# Altium schematic sheet Otto Rev E1.SchDoc
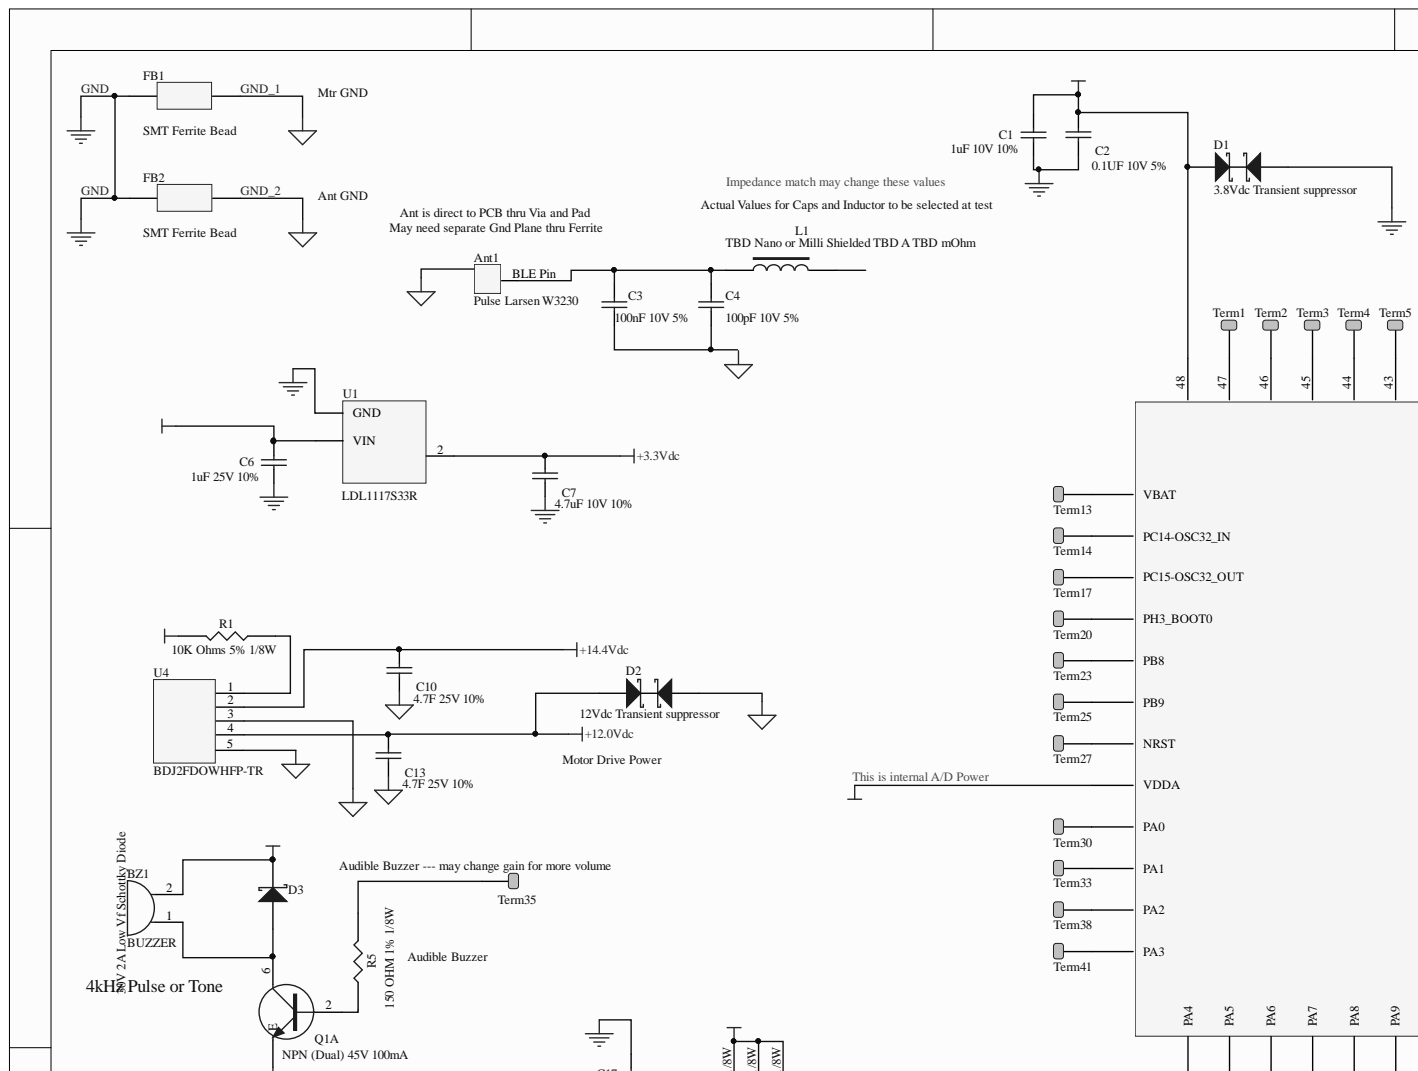
[diagram p0: Otto Rev E1.SchDoc, top left]
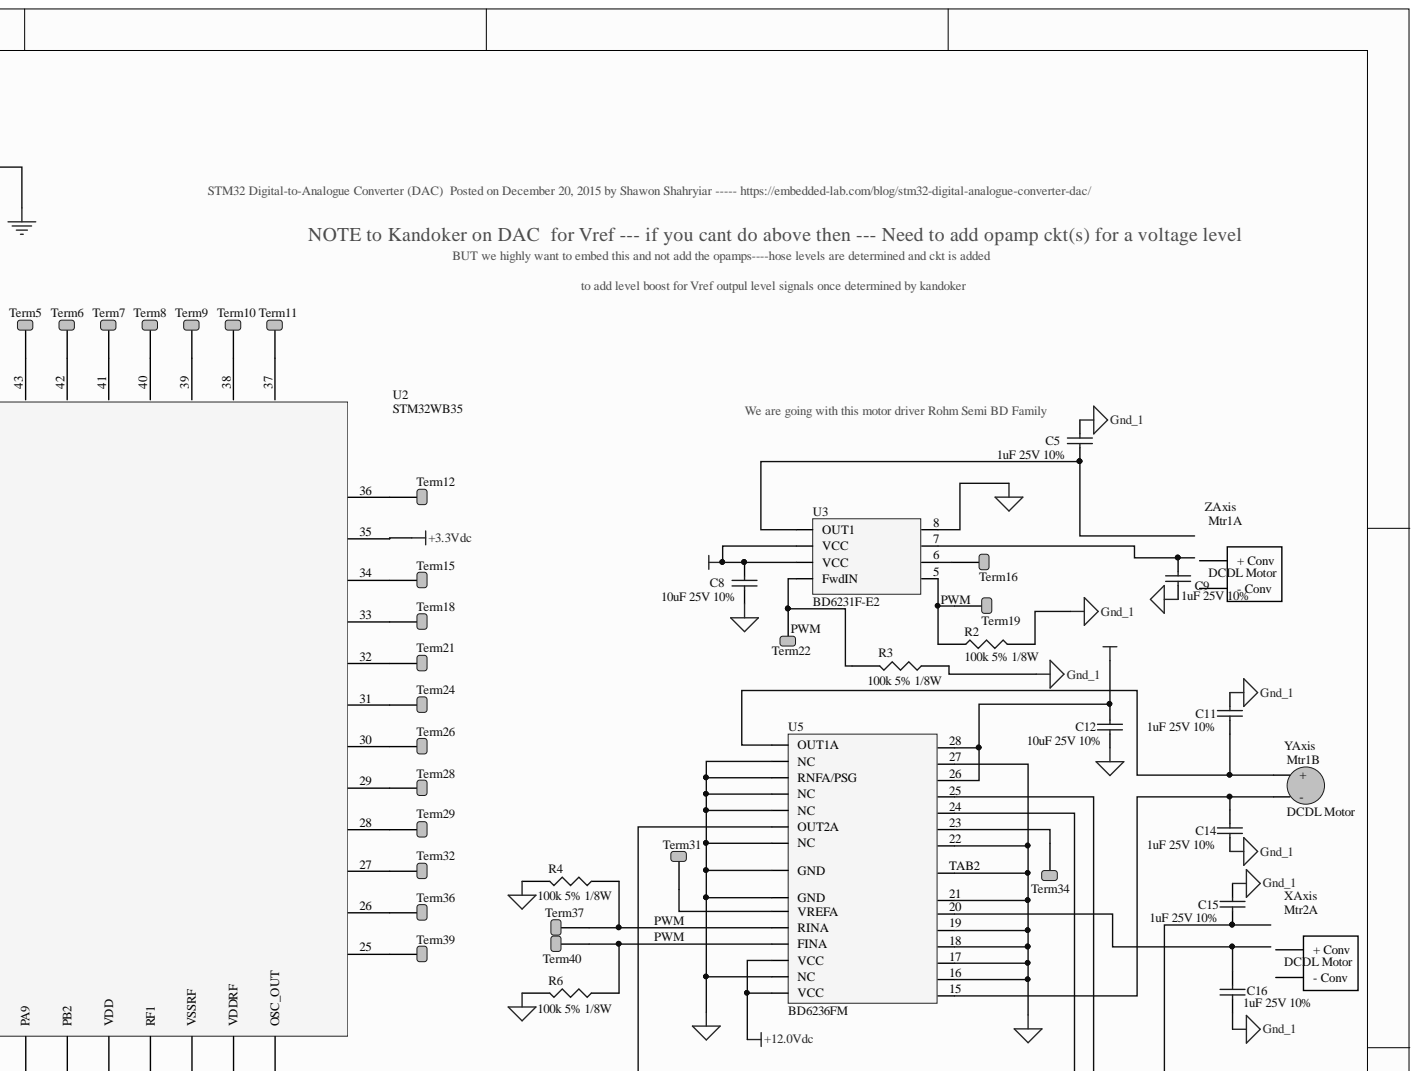
[diagram p1: Otto Rev E1.SchDoc, top right]
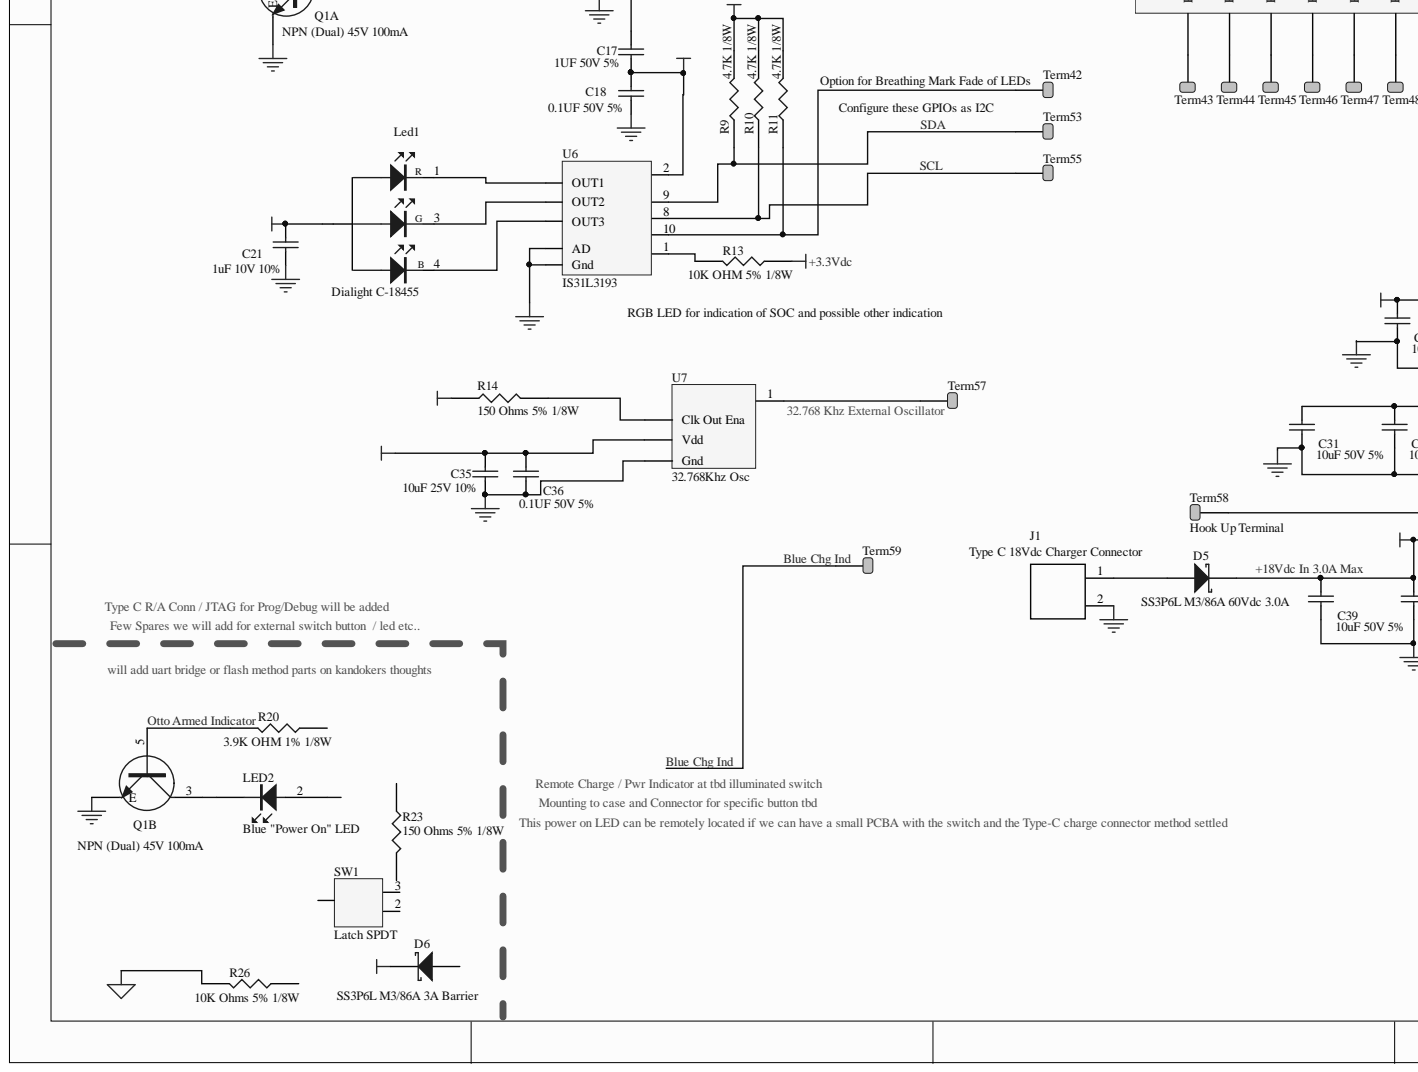
[diagram p2: Otto Rev E1.SchDoc, bottom left]
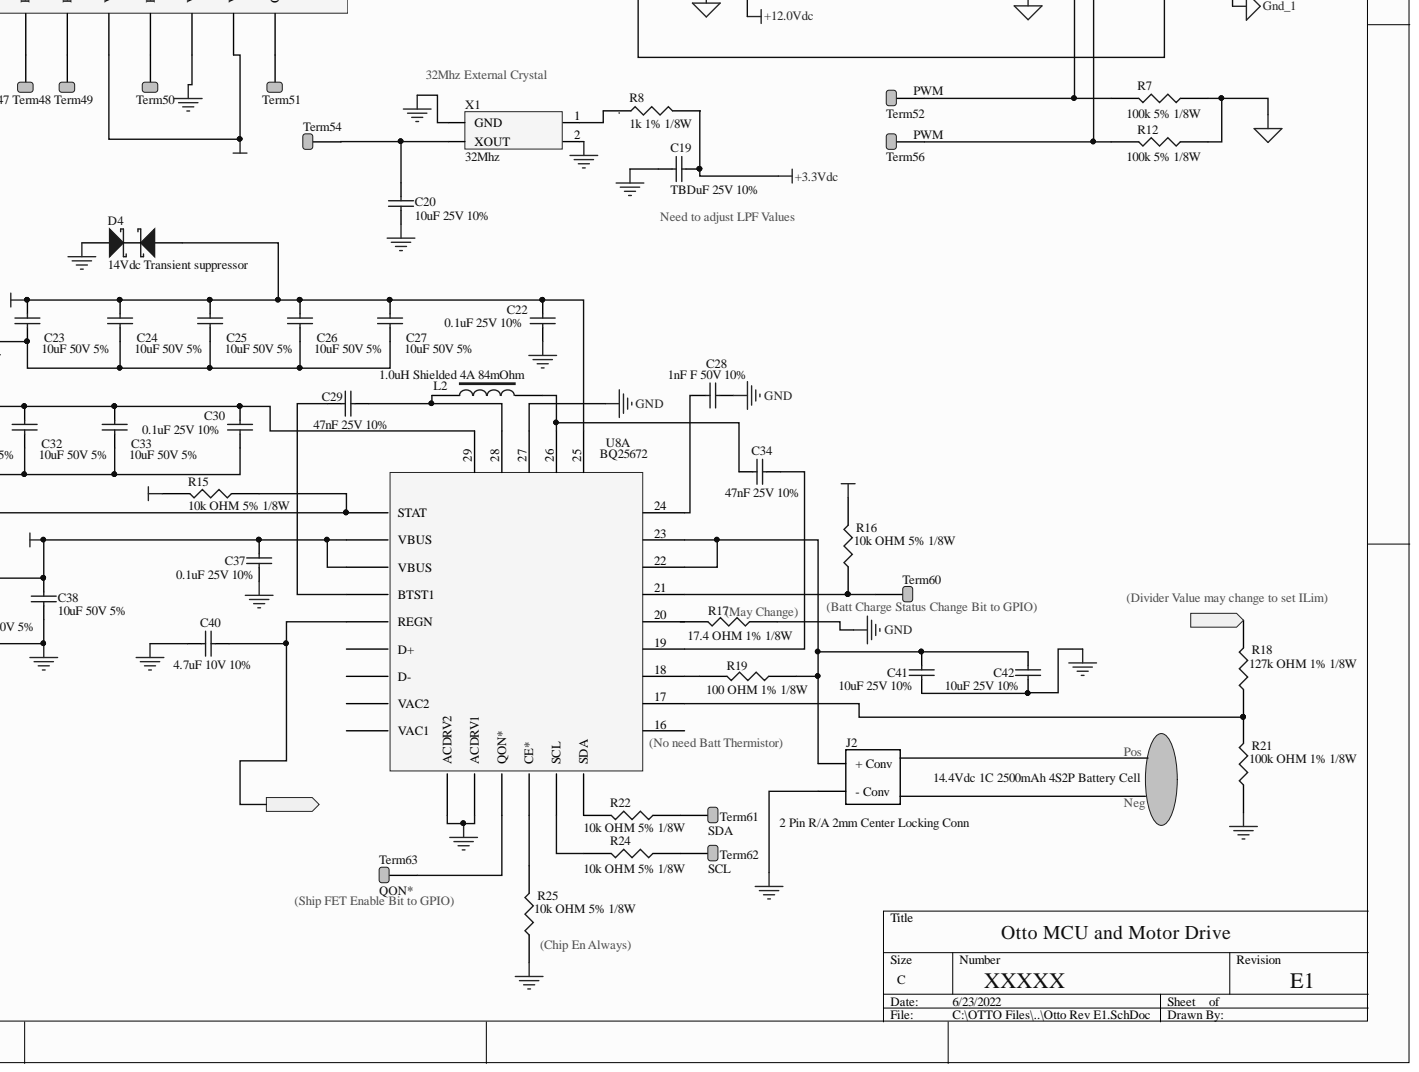
[diagram p3: Otto Rev E1.SchDoc, bottom right]

## Parts
Ant1  Pulse Larsen W3230
BZ1  BUZZER  [BUZZER-smd]
C1  1uF 10V 10%  [0805]
C2  0.1UF 10V 5%  [0603]
C3  100nF 10V 5%  [0805]
C4  100pF 10V 5%  [0603]
C5  1uF 25V 10%  [0805]
C6  1uF 25V 10%  [0805]
C7  4.7uF 10V 10%  [0603]
C8  10uF 25V 10%  [0805]
C9  1uF 25V 10%  [0805]
C10  4.7F 25V 10%  [0603]
C11  1uF 25V 10%  [0805]
C12  10uF 25V 10%  [0805]
C13  4.7F 25V 10%  [0603]
C14  1uF 25V 10%  [0805]
C15  1uF 25V 10%  [0805]
C16  1uF 25V 10%  [0805]
C17  1UF 50V 5%  [0603]
C18  0.1UF 50V 5%  [0603]
C19  TBDuF 25V 10%  [0805]
C20  10uF 25V 10%  [0805]
C21  1uF 10V 10%  [0603]
C22  0.1uF 25V 10%  [0805]
C23  10uF 50V 5%  [0603]
C24  10uF 50V 5%  [0603]
C25  10uF 50V 5%  [0603]
C26  10uF 50V 5%  [0603]
C27  10uF 50V 5%  [0603]
C28  1nF F 50V 10%  [0805]
C29  47nF 25V 10%  [0805]
C30  0.1uF 25V 10%  [0805]
C31  10uF 50V 5%  [0603]
C32  10uF 50V 5%  [0603]
C33  10uF 50V 5%  [0603]
C34  47nF 25V 10%  [0805]
C35  10uF 25V 10%  [0805]
C36  0.1UF 50V 5%  [0603]
C37  0.1uF 25V 10%  [0805]
C38  10uF 50V 5%  [0603]
C39  10uF 50V 5%  [0603]
C40  4.7uF 10V 10%  [0805]
C41  10uF 25V 10%  [0805]
C42  10uF 25V 10%  [0805]
D1  3.8Vdc Transient suppressor  [SOD 123]
D2  12Vdc Transient suppressor  [SOD 123]
D3  30V 2A Low Vf Schottky Diode  [SOD 123]
D4  14Vdc Transient suppressor  [SOD 123]
D5  SS3P6L M3/86A 60Vdc 3.0A  [Vishay Diode]
D6  SS3P6L M3/86A 3A Barrier  [Vishay Diode]
FB1  Text Field1: SMT Ferrite Bead  [0805]  (hidden comment: Max DCR 0.05ohm  3A)
FB2  Text Field1: SMT Ferrite Bead  [0805]  (hidden comment: Max DCR 0.05ohm  3A)
J1  Type C 18Vdc Charger Connector  [GTK 2 pin Batt  RA]
J2  2 Pin R/A 2mm Center Locking Conn  [GTK 2 pin Batt  RA]
L1  TBD Nano or Milli Shielded TBD A TBD mOhm  [4x4 INDUCTOR]
L2  1.0uH Shielded 4A 84mOhm  [4x4 INDUCTOR]
Led1  Dialight C-18455
LED2  Blue "Power On" LED  [LED]
Mtr1  DCDL Motor  [GTK 2 pin Batt  RA]  (parts A,B)
Mtr2  DCDL Motor  [GTK 2 pin Batt  RA]  (part A)
Q1  NPN (Dual) 45V 100mA  [SOT-363]  (parts A,B)
R1  10K Ohms 5% 1/8W  [0805]
R2  100k 5% 1/8W  [0805]
R3  100k 5% 1/8W  [0805]
R4  100k 5% 1/8W  [0805]
R5  150 OHM 1% 1/8W  [0805]
R6  100k 5% 1/8W  [0805]
R7  100k 5% 1/8W  [0805]
R8  1k 1% 1/8W  [0805]
R9  4.7K 1/8W  [0805]
R10  4.7K 1/8W  [0805]
R11  4.7K 1/8W  [0805]
R12  100k 5% 1/8W  [0805]
R13  10K OHM 5% 1/8W  [0805]
R14  150 Ohms 5% 1/8W  [0805]
R15  10k OHM 5% 1/8W  [0805]
R16  10k OHM 5% 1/8W  [0805]
R17  17.4 OHM 1% 1/8W  [0805]
R18  127k OHM 1% 1/8W  [0805]
R19  100 OHM 1% 1/8W  [0805]
R20  3.9K OHM 1% 1/8W  [0805]
R21  100k OHM 1% 1/8W  [0805]
R22  10k OHM 5% 1/8W  [0805]
R23  150 Ohms 5% 1/8W  [0805]
R24  10k OHM 5% 1/8W  [0805]
R25  10k OHM 5% 1/8W  [0805]
R26  10K Ohms 5% 1/8W  [0805]
SW1  Latch SPDT
Term1  Hook Up Terminal  [Vishay Diode]
Term2  Hook Up Terminal  [Vishay Diode]
Term3  Hook Up Terminal  [Vishay Diode]
Term4  Hook Up Terminal  [Vishay Diode]
Term5  Hook Up Terminal  [Vishay Diode]
Term6  Hook Up Terminal  [Vishay Diode]
Term7  Hook Up Terminal  [Vishay Diode]
Term8  Hook Up Terminal  [Vishay Diode]
Term9  Hook Up Terminal  [Vishay Diode]
Term10  Hook Up Terminal  [Vishay Diode]
Term11  Hook Up Terminal  [Vishay Diode]
Term12  Hook Up Terminal  [Vishay Diode]
Term13  Hook Up Terminal  [Vishay Diode]
Term14  Hook Up Terminal  [Vishay Diode]
Term15  Hook Up Terminal  [Vishay Diode]
Term16  Hook Up Terminal  [Vishay Diode]
Term17  Hook Up Terminal  [Vishay Diode]
Term18  Hook Up Terminal  [Vishay Diode]
Term19  Hook Up Terminal  [Vishay Diode]
Term20  Hook Up Terminal  [Vishay Diode]
Term21  Hook Up Terminal  [Vishay Diode]
Term22  Hook Up Terminal  [Vishay Diode]
Term23  Hook Up Terminal  [Vishay Diode]
Term24  Hook Up Terminal  [Vishay Diode]
Term25  Hook Up Terminal  [Vishay Diode]
Term26  Hook Up Terminal  [Vishay Diode]
Term27  Hook Up Terminal  [Vishay Diode]
Term28  Hook Up Terminal  [Vishay Diode]
Term29  Hook Up Terminal  [Vishay Diode]
Term30  Hook Up Terminal  [Vishay Diode]
Term31  Hook Up Terminal  [Vishay Diode]
Term32  Hook Up Terminal  [Vishay Diode]
Term33  Hook Up Terminal  [Vishay Diode]
Term34  Hook Up Terminal  [Vishay Diode]
Term35  Hook Up Terminal  [Vishay Diode]
Term36  Hook Up Terminal  [Vishay Diode]
Term37  Hook Up Terminal  [Vishay Diode]
Term38  Hook Up Terminal  [Vishay Diode]
Term39  Hook Up Terminal  [Vishay Diode]
Term40  Hook Up Terminal  [Vishay Diode]
Term41  Hook Up Terminal  [Vishay Diode]
Term42  Hook Up Terminal  [Vishay Diode]
Term43  Hook Up Terminal  [Vishay Diode]
Term44  Hook Up Terminal  [Vishay Diode]
Term45  Hook Up Terminal  [Vishay Diode]
Term46  Hook Up Terminal  [Vishay Diode]
Term47  Hook Up Terminal  [Vishay Diode]
Term48  Hook Up Terminal  [Vishay Diode]
Term49  Hook Up Terminal  [Vishay Diode]
Term50  Hook Up Terminal  [Vishay Diode]
Term51  Hook Up Terminal  [Vishay Diode]
Term52  Hook Up Terminal  [Vishay Diode]
Term53  Hook Up Terminal  [Vishay Diode]
Term54  Hook Up Terminal  [Vishay Diode]
Term55  Hook Up Terminal  [Vishay Diode]
Term56  Hook Up Terminal  [Vishay Diode]
Term57  Hook Up Terminal  [Vishay Diode]
Term58  Hook Up Terminal  [Vishay Diode]
Term59  Hook Up Terminal  [Vishay Diode]
Term60  Hook Up Terminal  [Vishay Diode]
Term61  SDA  [Vishay Diode]
Term62  SCL  [Vishay Diode]
Term63  QON*  [Vishay Diode]
U1  LDL1117S33R  [CONN-5]
U2  STM32WB35  [32 Pin]
U3  BD6231F-E2
U4  BDJ2FDOWHFP-TR  [CONN-4]
U5  BD6236FM
U6  IS31L3193
U7  32.768Khz Osc  [CONN-4]
U8  BQ25672  [SOP-8]  (part A)
X1  32Mhz

## Ports
REGN

## Nets
+3.3Vdc: BZ1.2 C1.1 C2.1 C7.1 C17.1 C18.1 C19.2 C21.1 C35.1 C36.1 D1.1 D3.1 Led1.2 R8.1 R9.2 R10.2 R11.2 R13.2 R14.1 R15.2 R16.2 U1.2 U2.8(VDDA) U2.20(VDD) U2.23(VDDRF) U2.35 U2.48 U6.2 U7.4(Vdd)
+12.0Vdc: C8.1 C12.1 C13.1 D2.1 U3.2(VCC) U3.3(VCC) U4.4 U5.12(VCC) U5.14(VCC) U5.26 U5.28
+14.4Vdc: C10.1 C22.1 C23.1 C24.1 C25.1 C26.1 C27.1 D4.2 U4.2 U8.25
+18Vdc: C6.1 C37.1 C38.2 C39.1 D5.1 R1.2 U1.3 U8.2(VBUS) U8.3(VBUS)
VCC: D6.1
Blue Chg Ind: Term59.1
GND: C1.2 C2.2 C6.2 C7.2 C17.2 C18.2 C19.1 C20.2 C21.2 C22.2 C23.2 C24.2 C25.2 C26.2 C27.2 C28.2 C30.2 C31.2 C32.2 C33.2 C37.2 C38.1 C39.2 C40.1 C41.2 C42.2 D1.2 D4.1 FB1.1 FB2.1 J1.2 J2.2 Q1.1(E) Q1.4(E) R17.2 R21.1 R25.1 U1.1 U2.22(VSSRF) U6.6(Gnd) U6.7(AD) U8.10(ACDRV2) U8.11(ACDRV1) U8.27 X1.2 X1.4(GND)
GND_1: C5.1 C8.2 C9.1 C10.2 C11.1 C12.2 C13.2 C14.2 C15.1 C16.1 C35.2 C36.2 D2.2 FB1.2 R2.2 R3.1 R4.2 R6.2 R7.1 R12.1 R26.2 U3.8 U4.3 U4.5 U5.2(NC) U5.3(RNFA/PSG) U5.4(NC) U5.5(NC) U5.7(NC) U5.8(GND) U5.13(NC) U5.16 U5.17 U5.18 U5.19 U5.21 U5.22 U5.27 U5.TAB1(GND) U5.TAB2 U7.2(Gnd)
GND_2: FB2.2
Otto Armed Indicator: Q1.5 R20.1
REGN: C40.2 R18.2 U8.5(REGN) ; port REGN
SCL: R10.1 Term55.1 U6.8
SDA: R9.1 Term53.1 U6.9
NetAnt1_BLE Pin: Ant1.BLE Pin C3.1 C4.1 L1.1
NetBZ1_1: BZ1.1 D3.2 Q1.6
NetC3_2: C3.2 C4.2
NetC5_2: C5.2 U3.1(OUT1)
NetC9_2: C9.2 U3.7
NetC11_2: C11.2 Mtr1.1 U5.1(OUT1A)
NetC14_1: C14.1 Mtr1.2 U5.15
NetC15_2: C15.2 U5.6(OUT2A)
NetC16_2: C16.2 U5.20
NetC20_1: C20.1 Term54.1 X1.3(XOUT)
NetC28_1: C28.1 U8.24
NetC29_1: C29.1 U8.4(BTST1)
NetC29_2: C29.2 L2.1 U8.28
NetC30_1: C30.1 C31.1 C32.1 C33.1 U8.29
NetC34_1: C34.1 L2.2 U8.26
NetC34_2: C34.2 U8.19
NetC41_1: C41.1 C42.1 J2.1 R19.2 U8.22 U8.23
NetD5_2: D5.2 J1.1
NetLed1_1: Led1.1 U6.3(OUT1)
NetLed1_3: Led1.3 U6.4(OUT2)
NetLed1_4: Led1.4 U6.5(OUT3)
NetLED2_1: LED2.1 Q1.3
NetQ1_2: Q1.2 R5.1
NetR1_1: R1.1 U4.1
NetR2_1: R2.1 Term19.1 U3.5
NetR3_2: R3.2 Term22.1 U3.4(FwdIN)
NetR4_1: R4.1 Term37.1 U5.10(RINA)
NetR5_2: R5.2 Term35.1
NetR6_1: R6.1 Term40.1 U5.11(FINA)
NetR7_2: R7.2 Term52.1 U5.24
NetR8_2: R8.2 X1.1
NetR11_1: R11.1 Term42.1 U6.10
NetR12_2: R12.2 Term56.1 U5.25
NetR13_1: R13.1 U6.1
NetR14_2: R14.2 U7.3(Clk_Out_Ena)
NetR15_1: R15.1 Term58.1 U8.1(STAT)
NetR16_1: R16.1 Term60.1 U8.21
NetR18_1: R18.1 R21.2 U8.17
NetR19_1: R19.1 U8.18
NetR22_1: R22.1 Term61.1
NetR22_2: R22.2 U8.15(SDA)
NetR24_1: R24.1 Term62.1
NetR24_2: R24.2 U8.14(SCL)
NetR25_2: R25.2 U8.13(CE*)
NetTerm1_1: Term1.1 U2.47
NetTerm2_1: Term2.1 U2.46
NetTerm3_1: Term3.1 U2.45
NetTerm4_1: Term4.1 U2.44
NetTerm5_1: Term5.1 U2.43
NetTerm6_1: Term6.1 U2.42
NetTerm7_1: Term7.1 U2.41
NetTerm8_1: Term8.1 U2.40
NetTerm9_1: Term9.1 U2.39
NetTerm10_1: Term10.1 U2.38
NetTerm11_1: Term11.1 U2.37
NetTerm12_1: Term12.1 U2.36
NetTerm13_1: Term13.1 U2.1(VBAT)
NetTerm14_1: Term14.1 U2.2(PC14-OSC32_IN)
NetTerm15_1: Term15.1 U2.34
NetTerm16_1: Term16.1 U3.6
NetTerm17_1: Term17.1 U2.3(PC15-OSC32_OUT)
NetTerm18_1: Term18.1 U2.33
NetTerm20_1: Term20.1 U2.4(PH3_BOOT0)
NetTerm21_1: Term21.1 U2.32
NetTerm23_1: Term23.1 U2.5(PB8)
NetTerm24_1: Term24.1 U2.31
NetTerm25_1: Term25.1 U2.6(PB9)
NetTerm26_1: Term26.1 U2.30
NetTerm27_1: Term27.1 U2.7(NRST)
NetTerm28_1: Term28.1 U2.29
NetTerm29_1: Term29.1 U2.28
NetTerm30_1: Term30.1 U2.9(PA0)
NetTerm31_1: Term31.1 U5.9(VREFA)
NetTerm32_1: Term32.1 U2.27
NetTerm33_1: Term33.1 U2.10(PA1)
NetTerm34_1: Term34.1 U5.23
NetTerm36_1: Term36.1 U2.26
NetTerm38_1: Term38.1 U2.11(PA2)
NetTerm39_1: Term39.1 U2.25
NetTerm41_1: Term41.1 U2.12(PA3)
NetTerm43_1: Term43.1 U2.13(PA4)
NetTerm44_1: Term44.1 U2.14(PA5)
NetTerm45_1: Term45.1 U2.15(PA6)
NetTerm46_1: Term46.1 U2.16(PA7)
NetTerm47_1: Term47.1 U2.17(PA8)
NetTerm48_1: Term48.1 U2.18(PA9)
NetTerm49_1: Term49.1 U2.19(PB2)
NetTerm50_1: Term50.1 U2.21(RF1)
NetTerm51_1: Term51.1 U2.24(OSC_OUT)
NetTerm57_1: Term57.1 U7.1
NetTerm63_1: Term63.1 U8.12(QON*)
+18Vdc In 3.0A Max:
GND _2:
N00011:
N00012:
N00013:

Not connected: D6 2; L1 2; LED2 2; Mtr2 1(+_Conv) 2(-_Conv); R17 1; R20 2; R23 1 2; R26 1; SW1 2 3 Out; U8 6(D+) 7(D-) 8(VAC2) 9(VAC1) 16 20

## Library data of the parts
Ant1 = BLE Antenna: BLE 2.5Ghz; Component Kind: Standard; Library Name: Otto Rev E1.SCHLIB
BZ1 = BUZZER: KLJ-5030 (Keliking); Component Kind: Standard
C1 C3 C19 C35 = CAP: GRM21BR61E106KA73K (Murata); Component Kind: Standard
C2 C17 C18 C36 = CAP: 06035C104JAT2A (AVX); Component Kind: Standard
C4 = CAP: change pn -- 6035C104JAT2A (AVX); Component Kind: Standard
C5 C9 C11 C12 C14-C16 = CAP: change pn needed -- GRM21BR61E106KA73K (Murata); Component Kind: Standard
C6 = CAP: need to change pn -- GRM21BR61E106KA73K (Murata); Component Kind: Standard
C7 C10 C13 = CAP: need to change pn -- 06035C104JAT2A (AVX); Component Kind: Standard
C8 = CAP: need partnumber change   --RM21BR61E106KA73K (Murata); Component Kind: Standard
C20 = CAP: GPN Change --- RM21BR61E106KA73K (Murata); Component Kind: Standard
C21 = CAP: need to change pn -- 6035C104JAT2A (AVX); Component Kind: Standard
C22 C30 C37 C41 C42 = CAP: change this GRM21BR61E106KA73K (Murata); Component Kind: Standard
C23-C27 C31-C33 C38 C39 = CAP: change this 06035C104JAT2A (AVX); Component Kind: Standard
C28 C40 = CAP: Change This   GRM21BR61E106KA73K (Murata); Component Kind: Standard
C29 C34 = CAP: Change this GRM21BR61E106KA73K (Murata); Component Kind: Standard
D1 = X1-DFN1006-2: Component Kind: Standard; Description: X1-DFN1006-2; Library Name: Otto Rev E1.SCHLIB
D2 = VS12VBA1HST15R: Component Kind: Standard; Description: VS12VBA1HST15R; Library Name: Otto Rev E1.SCHLIB
D3 = DIODE SCHOTTKY: MBR230LSFT1G (On Semi); Component Kind: Standard
D4 = 1.5SMBJ13A: Component Kind: Standard; Description: 1.5SMBJ13A; Library Name: Otto Rev E1.SCHLIB
D5 = 3A Diode_1: SS3P6L-M3/86A (Vishay); Component Kind: Standard; Library Name: Otto Rev E1.SCHLIB
D6 = 3A Diode: SS3P6L-M3/86A (Vishay); Component Kind: Standard; Library Name: Rev B Orion MCU.SCHLIB
FB1 FB2 = FERRITE BEAD: 2508051217Z3 (Fair-Rite); Component Kind: Standard
J1 J2 = 2 PIN .1"  HDR: 640457-2  (TE Connectivity); Component Kind: Standard
L1 L2 = INDUCTOR2: NR4018T3R3M (Taiyo Uden); Component Kind: Standard
Led1 = RGB LED: Component Kind: Standard; Description: Dialight C-18455; Library Name: Otto Rev E1.SCHLIB
LED2 = LED: 3MMLED-BLUE-CLR (https://www.jameco.com/z/3MMLED-BLUE-CLR-3mm-Blue-LED-with-Water-Clear-Lens_2283655.html?CID=MERCH); Component Kind: Standard
Mtr1 Mtr2 = DC Motor: DC Brushless; Component Kind: Standard; Library Name: Otto Rev E1.SCHLIB
Q1 = SOT-363: BC847BS-7FDICT (Diodes Inc); Component Kind: Standard
R1 = RES1: NEED TO CHANGE PN --- RC0805JR-07150RL (Yageo); Component Kind: Standard
R2 = RES1: need new pn -- C0805FR-07150RL (Yageo); Component Kind: Standard
R3 R4 R6 R7 R12 = RES1: need mew pn --- 0805FR-07150RL (Yageo); Component Kind: Standard
R5 R9-R11 = RES1: RC0805FR-07150RL (Yageo); Component Kind: Standard
R8 = RES1: PN change -- C0805FR-071K2L (Yageo); Component Kind: Standard; Library Name: Otto Rev E1.SCHLIB
R13 R20 = RES1: RC0805FR-073K9L (Yageo); Component Kind: Standard
R14 R23 R26 = RES1: RC0805JR-07150RL (Yageo); Component Kind: Standard
R15 R16 R18 R21 R22 R24 R25 = RES1: Change    from RC0805FR-07150RL (Yageo); Component Kind: Standard
R17 R19 = RES1: Change This RC0805FR-07100KL (Yageo); Component Kind: Standard
SW1 = SPDT: SPDT Pushbutton Latch GTE 3A; Component Kind: Standard; Library Name: Otto Rev E1.SCHLIB
Term61-Term63 = Hook Up Terminal: Component Kind: Standard; Description: Hook Up Terminal; Library Name: Otto Rev E1.SCHLIB
U1 = LDL1117S33R: ST 3.3Vdc LDO 1.2A; Component Kind: Standard; Library Name: Otto Rev E1.SCHLIB
U2 = STM32: Component Kind: Standard; Description: STM32WB35; Library Name: Otto Rev E1.SCHLIB
U3 = BD6231F-E2: DC Brush Mtr Driver; Component Kind: Standard; Library Name: Otto Rev E1.SCHLIB
U4 = BDJ2FDOWHFP-TR: ROHM LDO 12VDC 2A; Component Kind: Standard; Library Name: Otto Rev E1.SCHLIB
U5 = BD6236FM: DC Brush Mtr Driver 2 CH; Component Kind: Standard; Library Name: Otto Rev E1.SCHLIB
U6 = IS31FL3193 RGB Drive: RGB Driver; Component Kind: Standard; Library Name: Otto Rev E1.SCHLIB
U7 = 32.768Khz Osc: OM-7604-C7  - 32.768Khz Osc; Component Kind: Standard; Library Name: Otto Rev E1.SCHLIB
U8 = BQ25672: TI BQ 25672 Batt IC; Component Kind: Standard; Library Name: Otto Rev E1.SCHLIB
X1 = Xtal: 40Mhz Crystal; Component Kind: Standard; Library Name: Otto Rev E1.SCHLIB
Term1-Term60 = Hook Up Terminal: Component Kind: Standard; Description: Hook Up Terminal; Library Name: Otto Rev E1.SCHLIB
